# Altium schematic sheet tsop_mux.SchDoc
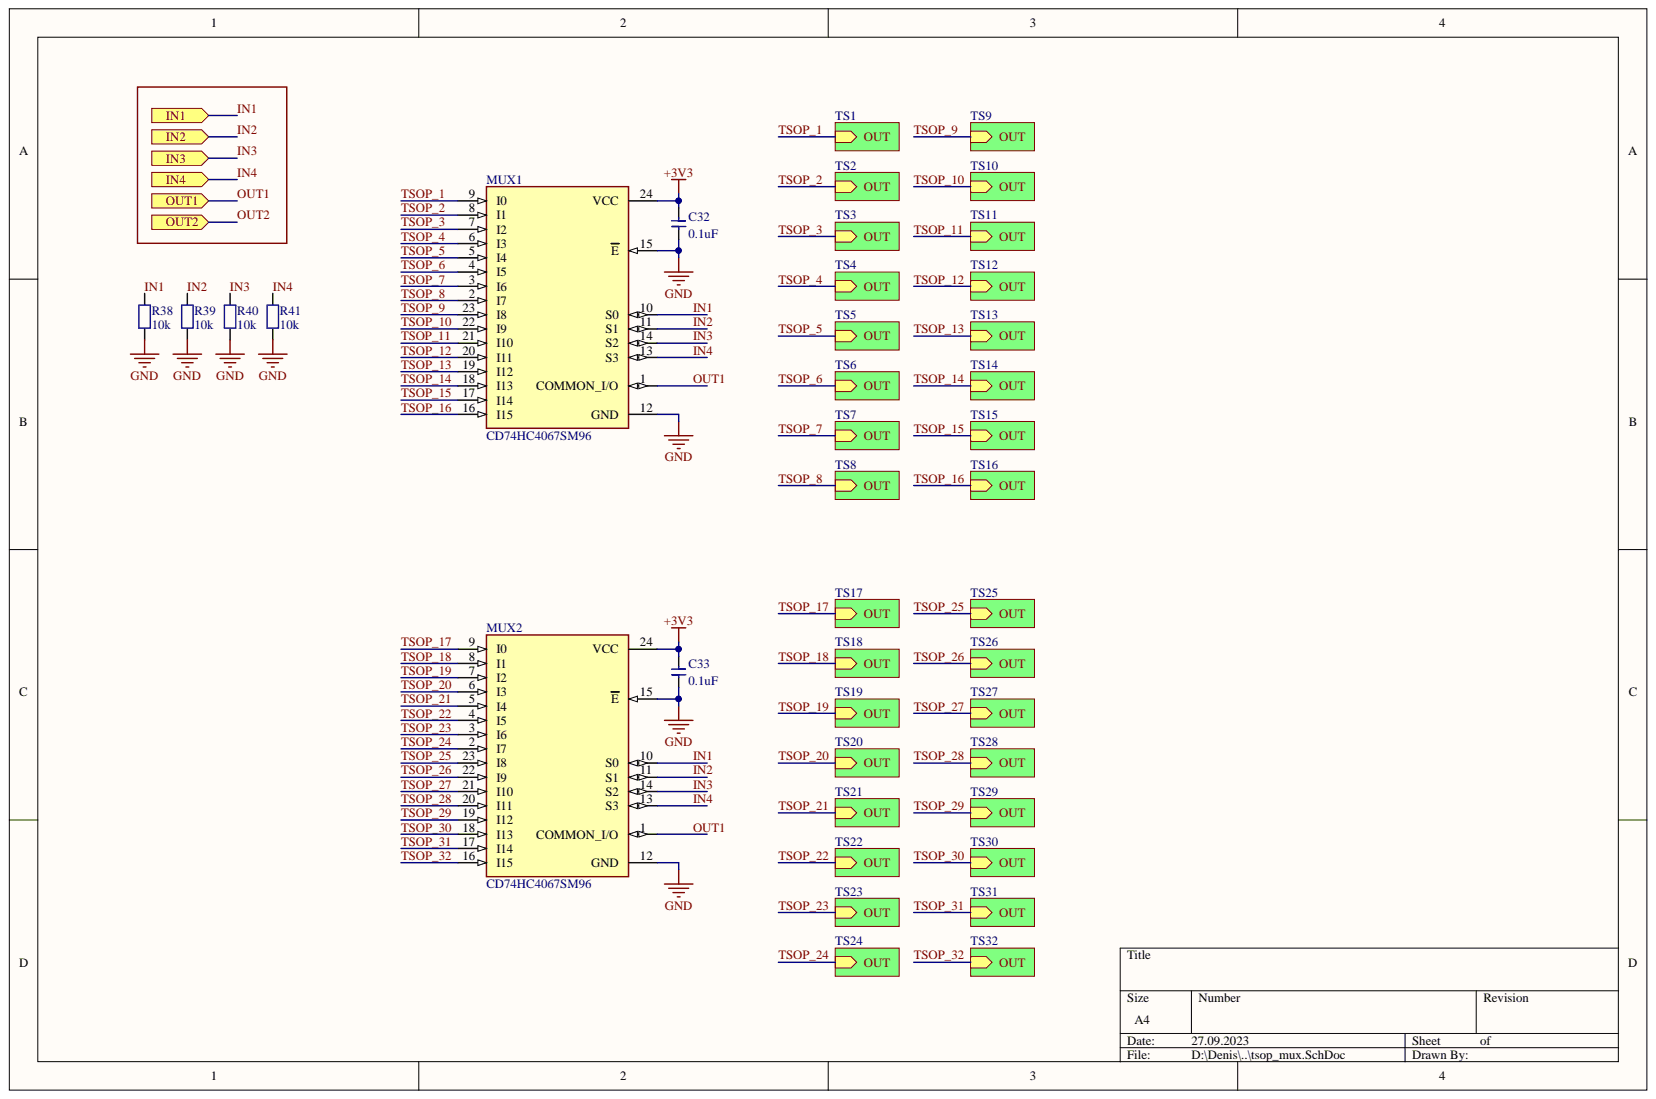
[diagram p0: tsop_mux.SchDoc]

## Parts
C32  0.1uF  [cap0603]
C33  0.1uF  [cap0603]
MUX1  CD74HC4067SM96  [CD74HC4067SM96]
MUX2  CD74HC4067SM96  [CD74HC4067SM96]
R38  10k  [res0402]
R39  10k  [res0402]
R40  10k  [res0402]
R41  10k  [res0402]

## Ports
IN1, IN2, IN3, IN4, OUT1, OUT2

## Nets
+3V3: C32.2 C33.2 MUX1.24(VCC) MUX2.24(VCC)
GND: C32.1 C33.1 MUX1.12(GND) MUX1.15(E) MUX2.12(GND) MUX2.15(E) R38.1 R39.1 R40.1 R41.1
IN1: MUX1.10(S0) MUX2.10(S0) R38.2 ; port IN1
IN2: MUX1.11(S1) MUX2.11(S1) R39.2 ; port IN2
IN3: MUX1.14(S2) MUX2.14(S2) R40.2 ; port IN3
IN4: MUX1.13(S3) MUX2.13(S3) R41.2 ; port IN4
OUT1: MUX1.1(COMMON_I/O) MUX2.1(COMMON_I/O) ; port OUT1
TSOP_1: MUX1.9(I0)
TSOP_2: MUX1.8(I1)
TSOP_3: MUX1.7(I2)
TSOP_4: MUX1.6(I3)
TSOP_5: MUX1.5(I4)
TSOP_6: MUX1.4(I5)
TSOP_7: MUX1.3(I6)
TSOP_8: MUX1.2(I7)
TSOP_9: MUX1.23(I8)
TSOP_10: MUX1.22(I9)
TSOP_11: MUX1.21(I10)
TSOP_12: MUX1.20(I11)
TSOP_13: MUX1.19(I12)
TSOP_14: MUX1.18(I13)
TSOP_15: MUX1.17(I14)
TSOP_16: MUX1.16(I15)
TSOP_17: MUX2.9(I0)
TSOP_18: MUX2.8(I1)
TSOP_19: MUX2.7(I2)
TSOP_20: MUX2.6(I3)
TSOP_21: MUX2.5(I4)
TSOP_22: MUX2.4(I5)
TSOP_23: MUX2.3(I6)
TSOP_24: MUX2.2(I7)
TSOP_25: MUX2.23(I8)
TSOP_26: MUX2.22(I9)
TSOP_27: MUX2.21(I10)
TSOP_28: MUX2.20(I11)
TSOP_29: MUX2.19(I12)
TSOP_30: MUX2.18(I13)
TSOP_31: MUX2.17(I14)
TSOP_32: MUX2.16(I15)
OUT2: ; port OUT2

## Library data of the parts
C32 C33 = Cap SMD: Component Kind: Standard; Library Name: GKirik.SchLib
MUX1 MUX2 = CD74HC4067SM96: SSOP-24; Component Kind: Standard; Library Name: Asterisk.SchLib
R38-R41 = Resistor SMD: Component Kind: Standard; Library Name: GKirik.SchLib
